# Altium schematic sheet RS422 Breakout.SchDoc
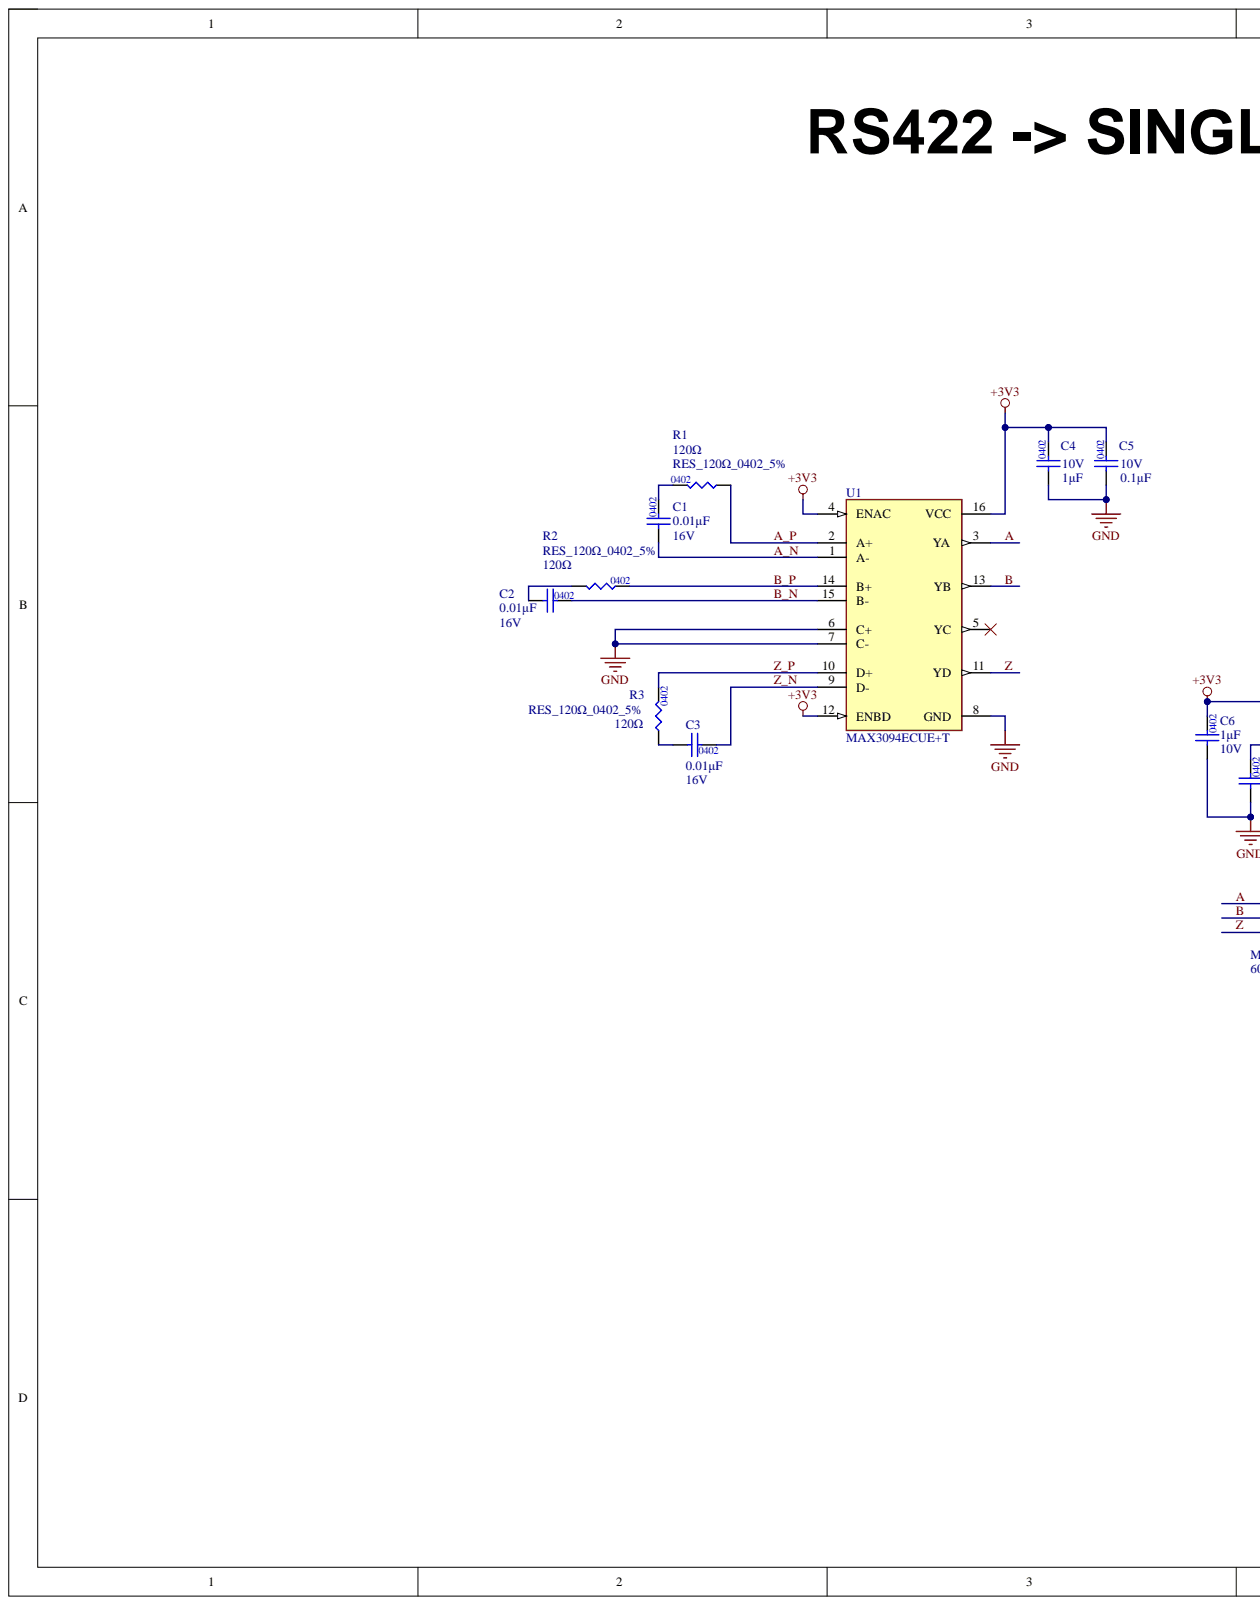
[diagram p0: RS422 Breakout.SchDoc, left]
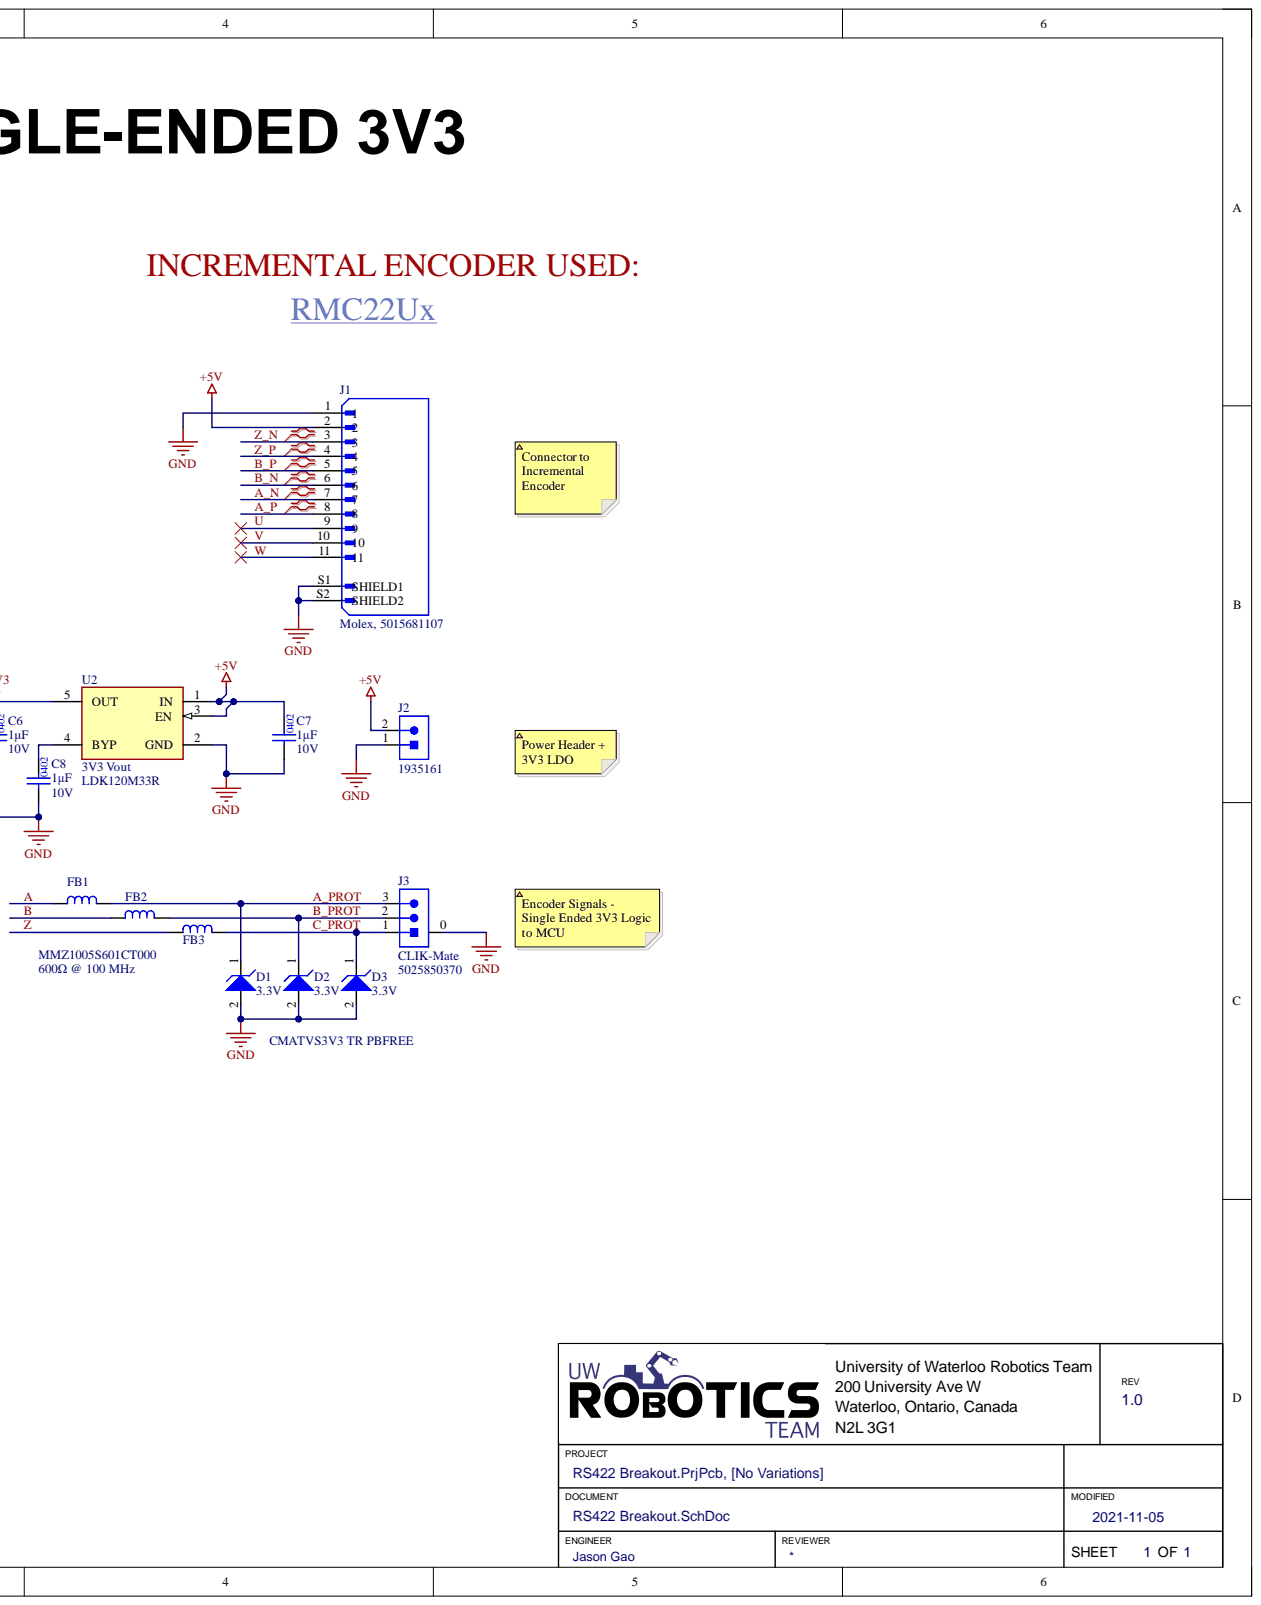
[diagram p1: RS422 Breakout.SchDoc, right]

## Parts
C1  Capacitance: 0.01μF  Voltage Rating: 16V  [CAP0402]  (hidden comment: CAP_0.01uF_16V_X7R_0402)
C2  Capacitance: 0.01μF  Voltage Rating: 16V  [CAP0402]  (hidden comment: CAP_0.01uF_16V_X7R_0402)
C3  Capacitance: 0.01μF  Voltage Rating: 16V  [CAP0402]  (hidden comment: CAP_0.01uF_16V_X7R_0402)
C4  Capacitance: 1μF  Package: 0402  Rated DC Voltage (URdc): 10V  [CAP0402]  (hidden comment: CAP_1UF_10V_0402_X5R)
C5  value 0.1µF  Package/Footprint: 0402  Voltage Rating: 10V  [CAP0402]  (hidden comment: CAP_0.1uF_10V_0402)
C6  Capacitance: 1μF  Package: 0402  Rated DC Voltage (URdc): 10V  [CAP0402]  (hidden comment: CAP_1UF_10V_0402_X5R)
C7  Capacitance: 1μF  Package: 0402  Rated DC Voltage (URdc): 10V  [CAP0402]  (hidden comment: CAP_1UF_10V_0402_X5R)
C8  Capacitance: 1μF  Package: 0402  Rated DC Voltage (URdc): 10V  [CAP0402]  (hidden comment: CAP_1UF_10V_0402_X5R)
D1  Voltage - Reverse Standoff (Typ): 3.3V  [SOD-923]  (hidden comment: TVS_DIODE_UNIDIR_3.3V_7A)
D2  Voltage - Reverse Standoff (Typ): 3.3V  [SOD-923]  (hidden comment: TVS_DIODE_UNIDIR_3.3V_7A)
D3  Manufacturer Part Number 1: CMATVS3V3 TR PBFREE  Voltage - Reverse Standoff (Typ): 3.3V  [SOD-923]  (hidden comment: TVS_DIODE_UNIDIR_3.3V_7A)
FB1  FERRITE_BEAD_600OHM_0402  [RES0402]  (value not drawn)
FB2  FERRITE_BEAD_600OHM_0402  [RES0402]  (value not drawn)
FB3  Manufacturer Part Number 1: MMZ1005S601CT000  [RES0402]  (hidden comment: FERRITE_BEAD_600OHM_0402)
J1  MP: Molex, 5015681107  [MOLEX-5015681107]  (hidden comment: CON_12M_SM_RA)
J2  CON_2POS_SCREW_TERM  [1935161]  (value not drawn)
J3  Family: CLIK-Mate  Manufacturer Part Number 1: 5025850370  [MOLEX-5025850370]  (hidden comment: CON_3M_SM_RA)
R1  RES_120Ω_0402_5%  value 120Ω  Package/Footprint: 0402  [RES0402]
R2  RES_120Ω_0402_5%  value 120Ω  Package/Footprint: 0402  [RES0402]
R3  RES_120Ω_0402_5%  value 120Ω  Package/Footprint: 0402  [RES0402]
U1  MAX3094ECUE+T  [SOP65P640X110-16N]
U2  Manufacturer Part Number: LDK120M33R  Rating: 3V3 Vout  [SOT23-5]  (hidden comment: LDO_3.3V_200mA)

## Nets
+3V3: C4.2 C5.2 C6.2 U1.4(ENAC) U1.12(ENBD) U1.16(VCC) U2.5(OUT)
+5V: C7.2 J1.2 J2.2 U2.1(IN) U2.3(EN)
GND: C4.1 C5.1 C6.1 C7.1 C8.1 D1.2 D2.2 D3.2 J1.1 J1.S1(SHIELD1) J1.S2(SHIELD2) J2.1 J3.0 U1.6(C+) U1.7(C-) U1.8(GND) U2.2(GND)
A: FB1.1 U1.3(YA)
A_N: C1.1 J1.7 U1.1(A-)
A_P: J1.8 R1.1 U1.2(A+)
A_PROT: D1.1 FB1.2 J3.3
B: FB2.1 U1.13(YB)
B_N: C2.2 J1.6 U1.15(B-)
B_P: J1.5 R2.2 U1.14(B+)
B_PROT: D2.1 FB2.2 J3.2
C_PROT: D3.1 FB3.2 J3.1
U: J1.9
V: J1.10
W: J1.11
Z: FB3.1 U1.11(YD)
Z_N: C3.2 J1.3 U1.9(D-)
Z_P: J1.4 R3.2 U1.10(D+)
NetC1_2: C1.2 R1.2
NetC2_1: C2.1 R2.1
NetC3_1: C3.1 R3.1
NetC8_2: C8.2 U2.4(BYP)

Not connected: U1 5(YC)

## Library data of the parts
C1-C3 = CAP_0.01uF_16V_X7R_0402: CAP CER 0.01uF 16V X7R 0402; Manufacturer: KEMET; Manufacturer Part Number: C0402C103J4RACTU; Component Kind: Standard; Mounting Type: Surface Mount; Supplier 1: Digi-Key; Supplier Part Number 1: 399-1039-1-ND; Library Name: UWRT_Schematic_Library_2020.SchLib
C4 C6-C8 = CAP_1UF_10V_0402_X5R: CAP CER 1UF 10V X5R 0402; Manufacturer: Kyocera AVX; Manufacturer Part Number: 0402ZD105MAT2A; Component Kind: Standard; ComponentLink7Description: https://datasheet.ciiva.com/11531/cx5r-11531784.pdf?src-supplier=Avnet; ComponentLink7URL: https://datasheet.ciiva.com/11531/cx5r-11531784.pdf?src-supplier=Avnet; Mounting Type: Surface Mount; Supplier 1: Digi-Key; Supplier Part Number 1: 478-5768-1-ND; Temperature Characteristics Code: X5R; Library Name: UWRT_Schematic_Library_2020.SchLib
C5 = CAP_0.1uF_10V_0402: CAP CER 0.1UF 10V X7R 0402; Manufacturer 1: Murata Electronics; Manufacturer Part Number 1: GRM155R71A104KA01D; Component Kind: Standard; Supplier 1: Digi-Key; Supplier Part Number 1: 490-6321-1-ND; Library Name: UWRT_Schematic_Library_2020.SchLib
D1 D2 = TVS_DIODE_UNIDIR_3.3V_7A: TVS DIODE 3.3VWM 11VC SOD923; Manufacturer 1: Central Semiconductor Corp; Manufacturer Part Number 1: CMATVS3V3 TR PBFREE; Voltage - Breakdown (Min): 5V; Voltage - Clamping (Max) @ Ipp: 11V; Component Kind: Standard; Mounting Type: SMD; Package/Footprint: SOD-923; Supplier 1: Digi-Key; Supplier Part Number 1: 1514-CMATVS3V3TRPBFREECT-ND; Library Name: UWRT_Schematic_Library_2020.SchLib
D3 = TVS_DIODE_UNIDIR_3.3V_7A: TVS DIODE 3.3VWM 11VC SOD923; Manufacturer 1: Central Semiconductor Corp; Voltage - Breakdown (Min): 5V; Voltage - Clamping (Max) @ Ipp: 11V; Component Kind: Standard; Mounting Type: SMD; Package/Footprint: SOD-923; Supplier 1: Digi-Key; Supplier Part Number 1: 1514-CMATVS3V3TRPBFREECT-ND; Library Name: UWRT_Schematic_Library_2020.SchLib
FB1 FB2 = FERRITE_BEAD_600OHM_0402: FIXED IND 1UH 3.8A 33 MOHM SMD; Manufacturer 1: TDK Corporation; Manufacturer Part Number 1: MMZ1005S601CT000; Current Rating (Max): 300mA; DC Resistance (DCR) (Max): 520mΩ; Impedance: 600Ω; Impedance @ Frequency: 600Ω @ 100 MHz; Component Kind: Standard; Mounting Type: SMD; Package: 0402; Package/Footprint: SMD; Supplier 1: Digi-Key; Supplier Part Number 1: 445-2162-1-ND; Library Name: UWRT_Schematic_Library_2020.SchLib
FB3 = FERRITE_BEAD_600OHM_0402: FIXED IND 1UH 3.8A 33 MOHM SMD; Manufacturer 1: TDK Corporation; Current Rating (Max): 300mA; DC Resistance (DCR) (Max): 520mΩ; Impedance: 600Ω; Impedance @ Frequency: 600Ω @ 100 MHz; Component Kind: Standard; Mounting Type: SMD; Package: 0402; Package/Footprint: SMD; Supplier 1: Digi-Key; Supplier Part Number 1: 445-2162-1-ND; Library Name: UWRT_Schematic_Library_2020.SchLib
J1 = CON_11M_SM_RA: CONN HEADER SMD RIGHT ANGLE 1MM PITCH; Availability: Unavailable; Component Kind: Standard; Package: None; Price: None; Library Name: templocal.SchLib
J2 = CON_2POS_SCREW_TERM: TERM BLK 2POS SIDE ENTRY 5MM; Manufacturer 1: Phoenix Contact; Package/Footprint: SMD; Component Kind: Standard; Manufacturer Part Number 1: 1935161; Mounting Type: TH; Supplier 1: Digi-Key; Supplier Part Number 1: 277-1667-ND; Library Name: UWRT_Schematic_Library_2020.SchLib
J3 = CON_3M_SM_RA: CONN RCPT 3POS 0.059 TIN SMD R/A; Manufacturer 1: Molex; Current Rating: 2A; Pitch: 1.5mm; Voltage Rating: 100V; Component Kind: Standard; Mounting Type: SMD; Orientation: Right Angle; Package/Footprint: SMD; Supplier 1: Digi-Key; Supplier Part Number 1: WM12831CT-ND; Library Name: UWRT_Schematic_Library_2020.SchLib
R1-R3 = RES_120Ω_0402_5%: RES 120 OHM 5% 1/16W 0402; Manufacturer 1: Yageo; Manufacturer Part Number 1: RC0402JR-07120RL; Component Kind: Standard; Mounting Type: SMD; Power: 1/16W; Supplier 1: Digi-Key; Supplier Part Number 1: 311-120JRCT-ND; Tolerance: 5%; Library Name: UWRT_Schematic_Library_2020.SchLib
U1 = MAX3094ECUE+T: IC RECEIVER 0/4 16TSSOP; Rating: 3 - 3.6Vin; Component Kind: Standard; Manufacturer Part Number: MAX3094ECUE+T; Library Name: UWRT_Schematic_Library_2020.SchLib
U2 = LDO_3.3V_200mA: IC REG LINEAR 3.3V 200MA SOT23-5; Component Kind: Standard; Library Name: UWRT_Schematic_Library_2020.SchLib
